# Altium schematic sheet PAGE2-RPI,RAK831,POWER.SchDoc
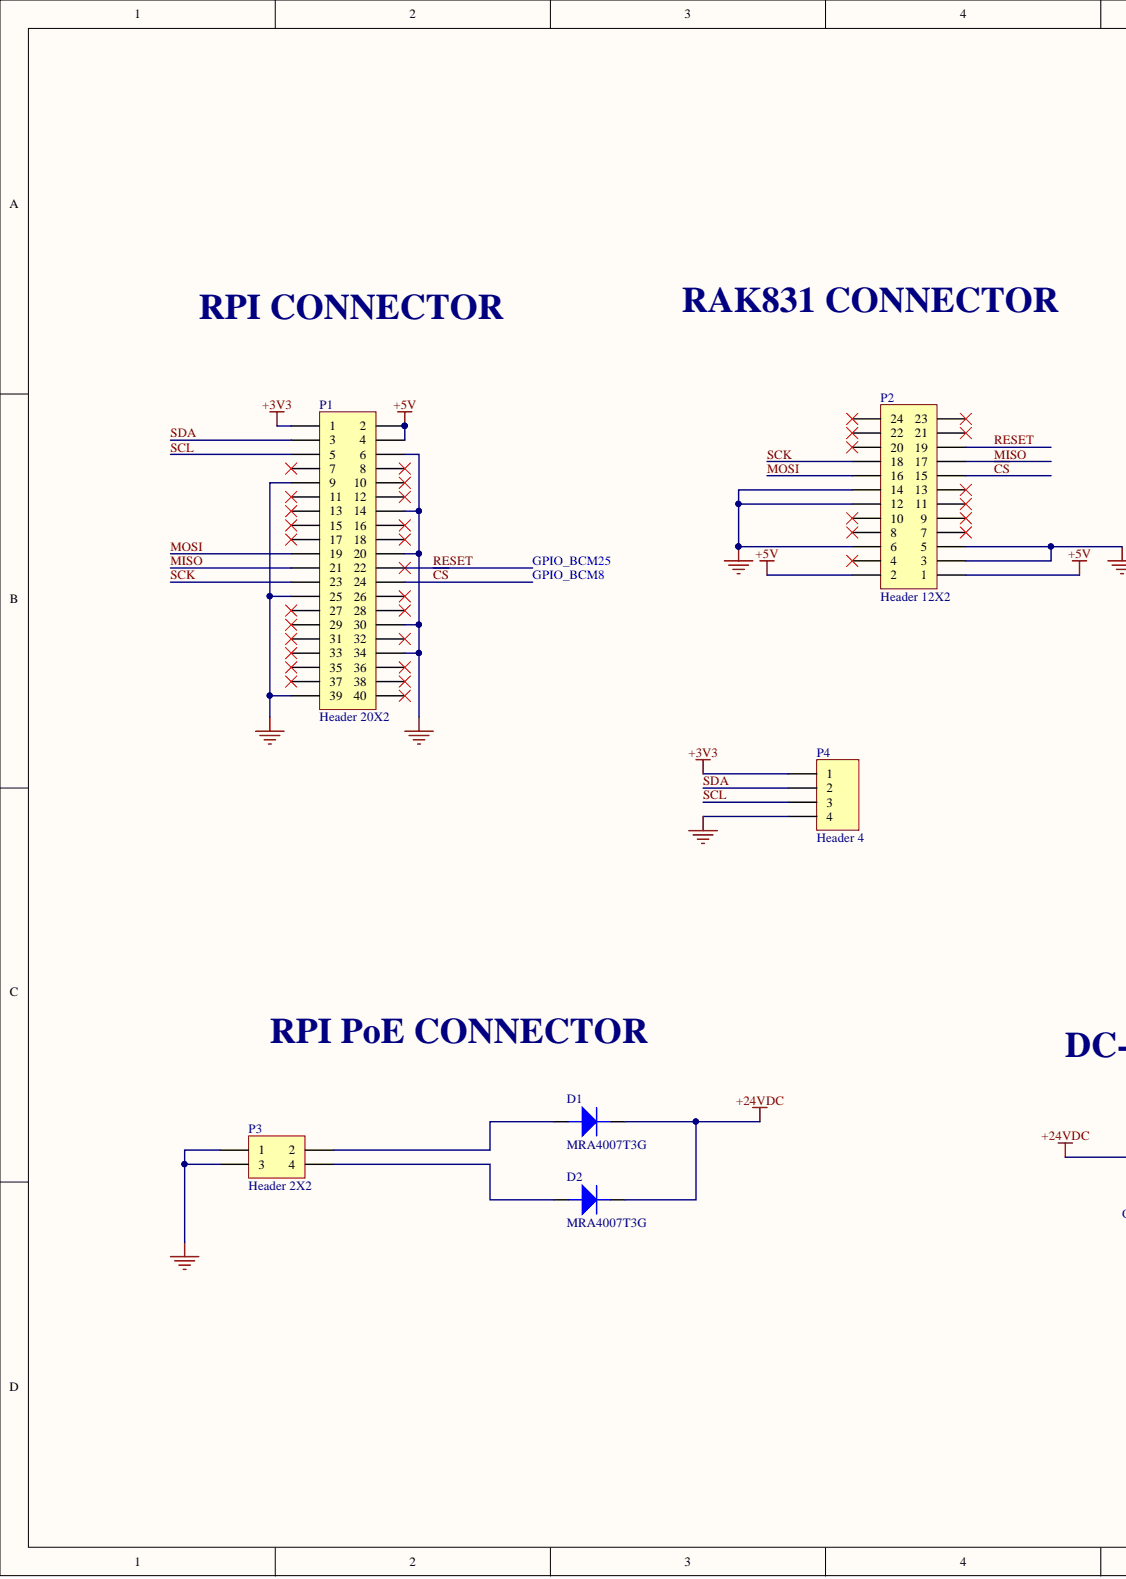
[diagram p0: PAGE2-RPI,RAK831,POWER.SchDoc, left]
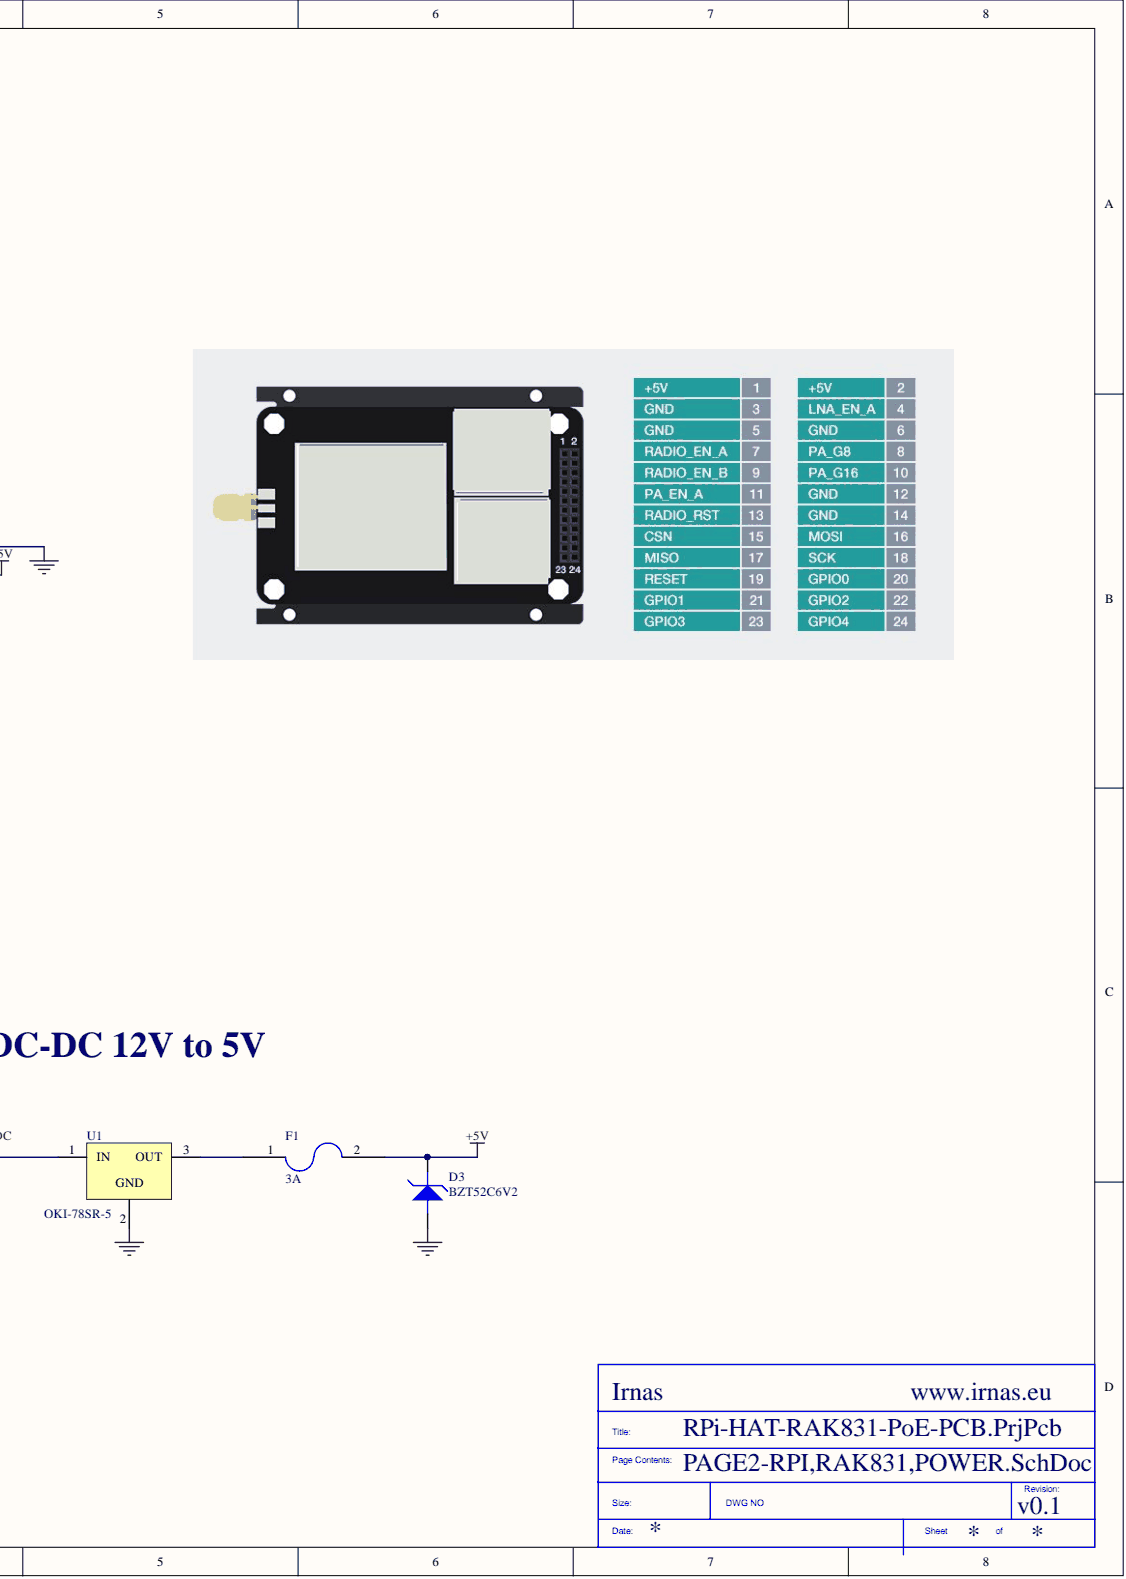
[diagram p1: PAGE2-RPI,RAK831,POWER.SchDoc, right]

## Parts
D1  MRA4007T3G  [DIOM4326X24N_1]
D2  MRA4007T3G  [DIOM4326X24N_1]
D3  BZT52C6V2  [SOD123]
F1  3A  [Chip2PinSM127P600-8N]
P1  Header 20X2  [HDR2X20]  (no BOM)
P2  Header 12X2  [HDR2X12]
P3  Header 2X2  [HDR2X2]
P4  Header 4  [HDR1X4]
U1  OKI-78SR-5  [DC-DC]

## Nets
+3V3: P1.1 P4.1
+5V: D3.1 F1.2 P1.2 P1.4 P2.1 P2.2
+24VDC: D1.1 D2.1 U1.1
GND: D3.2 P1.6 P1.9 P1.14 P1.20 P1.25 P1.30 P1.34 P1.39 P2.3 P2.5 P2.6 P2.12 P2.14 P3.1 P3.3 P4.4 U1.2
~{CS}: P1.24 P2.15
MISO: P1.21 P2.17
MOSI: P1.19 P2.16
RESET: P1.22 P2.19
SCK: P1.23 P2.18
SCL: P1.5 P4.3
SDA: P1.3 P4.2
NetD1_2: D1.2 P3.2
NetD2_2: D2.2 P3.4
NetF1_1: F1.1 U1.3

Not connected: P1 7 8 10 11 12 13 15 16 17 18 26 27 28 29 31 32 33 35 36 37 38 40; P2 4 7 8 9 10 11 13 20 21 22 23 24

## Library data of the parts
D1 D2 = MRA4007T3G: ON SEMICONDUCTOR - MRA4007T3G - DIODE, STANDARD, 1A, 1KV, SMA; Component Kind: Standard; ComponentLink1Description: http://www.onsemi.com/PowerSolutions/supportDoc.do?type=models&rpn=MRA4007; ComponentLink1URL: http://www.onsemi.com/PowerSolutions/supportDoc.do?type=models&rpn=MRA4007; ComponentLink2Description: http://www.farnell.com/datasheets/2028669.pdf; ComponentLink2URL: http://www.farnell.com/datasheets/2028669.pdf; ComponentLink3Description: http://www.farnell.com/datasheets/2037280.pdf; ComponentLink3URL: http://www.farnell.com/datasheets/2037280.pdf; Library Name: Diodes.IntLib; Manufacturer: ON SEMICONDUCTOR; Manufacturer Part Number: MRA4007T3G; Pricing 1: 10=0.181, 100=0.0749, 500=0.0692, 1000=0.0478, 2500=0.0401 (GBP); RoHS: YES; Stock 1: 92210; Supplier 1: Farnell; Supplier Part Number 1: 1459137
D3 = BZT52C6V2: DIODES INC. - BZT52C6V2 - DIODE, ZENER, 6.2V, 0.5W, SOD-123; No. of Pins: 2; Power Dissipation Pd: 500; Product Range: BZT52 Series; SVHC: No SVHC (12-Jan-2017); Zener Tolerance ±: 6%; Zener Voltage Vz Typ: 6.2; Automotive Qualification Standard: -; Component Kind: Standard; ComponentLink1Description: http://www.farnell.com/datasheets/1670962.pdf; ComponentLink1URL: http://www.farnell.com/datasheets/1670962.pdf; Diode Case Style: SOD-123; Library Name: Diodes.IntLib; Manufacturer: DIODES INC.; Manufacturer Part Number: BZT52C6V2; MSL: MSL 1 - Unlimited; Operating Temperature Max: 150; Operating Temperature Min: -65; Operating Temperature Range: -65°C to +150°C<!--FANDB--><div class="features-and-benefits-container"><div class="features-and-benefits-desc-container"><span class="features-and-benefits-container-desc">Zener Single Diode, 6.2 V, 500 mW, SOD-123, 6 %, 2 Pins, 150 °C</span></div><div class="features-and-benefits-description"><span class="features-benefits-value">The BZT52C6V2 is a surface mount Zener Diode with moulded plastic case, matte tin annealed over Alloy 42 lead frame (lead-free plating) terminals and cathode band polarity. It is ideally suited for automated assembly processes.</span></div><div class="features-and-benefits-list"><ul class="features-and-benefits-list-list"><li class="features-and-benefits-list-item">Planar die construction</li><li class="features-and-benefits-list-item">500mW Power dissipation</li><li class="features-and-benefits-list-item">General purpose, medium current</li><li class="features-and-benefits-list-item">Totally lead-free</li><li class="features-and-benefits-list-item">Halogen and antimony-free - Green device</li><li class="features-and-benefits-list-item">Qualified to AEC-Q101 standards for high reliability</li></ul></div><div class="features-and-benefits-applications"><ul class="features-and-benefits-value"><li class="features-and-benefits-list-item">Automation & Process Control</li></ul></div></div>; Packaging: Cut Tape; Pricing 1: 1=0.415, 25=0.264, 100=0.0727, 250=0.0546, 500=0.047, 1000=0.0334, 3000=0.0278, 7000=0.0236 (GBP); RoHS: YES; Stock 1: 4408; Supplier 1: Farnell; Supplier Part Number 1: 1902438
F1 = PTC FUSE 3A: LITTELFUSE - MINISMDC150F/24-2 - POLYSWITCH, SMD, 1812, 1.5A; Component Kind: Standard; ComponentLink1Description: http://www.farnell.com/datasheets/2363925.pdf; ComponentLink1URL: http://www.farnell.com/datasheets/2363925.pdf; ComponentLink2Description: http://www.farnell.com/datasheets/2282569.pdf; ComponentLink2URL: http://www.farnell.com/datasheets/2282569.pdf; Library Name: Fuse.IntLib; Manufacturer: LITTELFUSE; Manufacturer Part Number: MINISMDC150F/24-2; Pricing 1: 1=0.463, 50=0.382, 100=0.327, 250=0.302, 500=0.256, 1000=0.254, 5000=0.253, 10000=0.251 (GBP); RoHS: YES; Stock 1: 9256; Supplier 1: Farnell; Supplier Part Number 1: 1345929
P1 = Header 20X2: Header, 20-Pin, Dual row; LatestRevisionDate: 17-Jul-2002; LatestRevisionNote: Re-released for DXP Platform.; Library Name: Miscellaneous Connectors.IntLib; Signal Integrity: Connector; Publisher: Altium Limited
P2 = Header 12X2: Header, 12-Pin, Dual row; Component Kind: Standard; LatestRevisionDate: 17-Jul-2002; LatestRevisionNote: Re-released for DXP Platform.; Library Name: Miscellaneous Connectors.IntLib; Signal Integrity: Connector; Publisher: Altium Limited
P3 = Header 2X2: Header, 2-Pin, Dual row; Component Kind: Standard; LatestRevisionDate: 17-Jul-2002; LatestRevisionNote: Re-released for DXP Platform.; Library Name: Miscellaneous Connectors.IntLib; Signal Integrity: Connector; Publisher: Altium Limited
P4 = Header 4: Header, 4-Pin; Component Kind: Standard; LatestRevisionDate: 17-Jul-2002; LatestRevisionNote: Re-released for DXP Platform.; Library Name: Miscellaneous Connectors.IntLib; Signal Integrity: Connector; Publisher: Altium Limited
U1 = OKI-78SR-5/1.5-W36H-C: Non-Isolated DC/DC Converters 7.5W 24V 5Vout 1.5A Horizontal Mount; Manufacturer: Murata; Efficiency: 90.5%; Max Input Voltage: 36V; Max Power Dissipation: 8W; Min Input Voltage: 7V; Number of Outputs: 1; Output Voltage: 5V; Case/Package: SIP; Component Kind: Standard; ComponentLink1Description: Datasheet; ComponentLink1URL: http://www.farnell.com/datasheets/2258973.pdf?_ga=2.59674478.2140667653.1536586666-1732261692.1498238018; Current - Output 1: 1.5A; Depth: 16.5mm; Features: SCP; Height: 0.65inch; Isolation: Non-Isolated; Lead Free: Lead Free; Length: 10.4mm; Library Name: DCDC_converter.IntLib; Manufacturer Part Number: OKI-78SR-5/1.5-W36H-C; Max Operating Temperature: 85°C; Max Output Current: 1.5A; Min Operating Temperature: -40°C; Mount: Through Hole; Nominal Input Voltage: 24V; Number of Pins: 3; Output Current: 1.5A; Output Power: 7.5W; Package Quantity: 100; Packaging: Tray; Stock 1: 0; Supplier 1: Farnell; Supplier 2: Mouser; Supplier 3: Digi-Key; Supplier Part Number 1: 2766437; Supplier Part Number 2: 580-OKI78SR51.5W36HC; Supplier Part Number 3: 811-2692-ND; Voltage - Output 1: 5V; Weight: 2g; Width: 0.3inch
